# Altium schematic sheet MicroBitArcadeShieldMVD 08.SchDoc
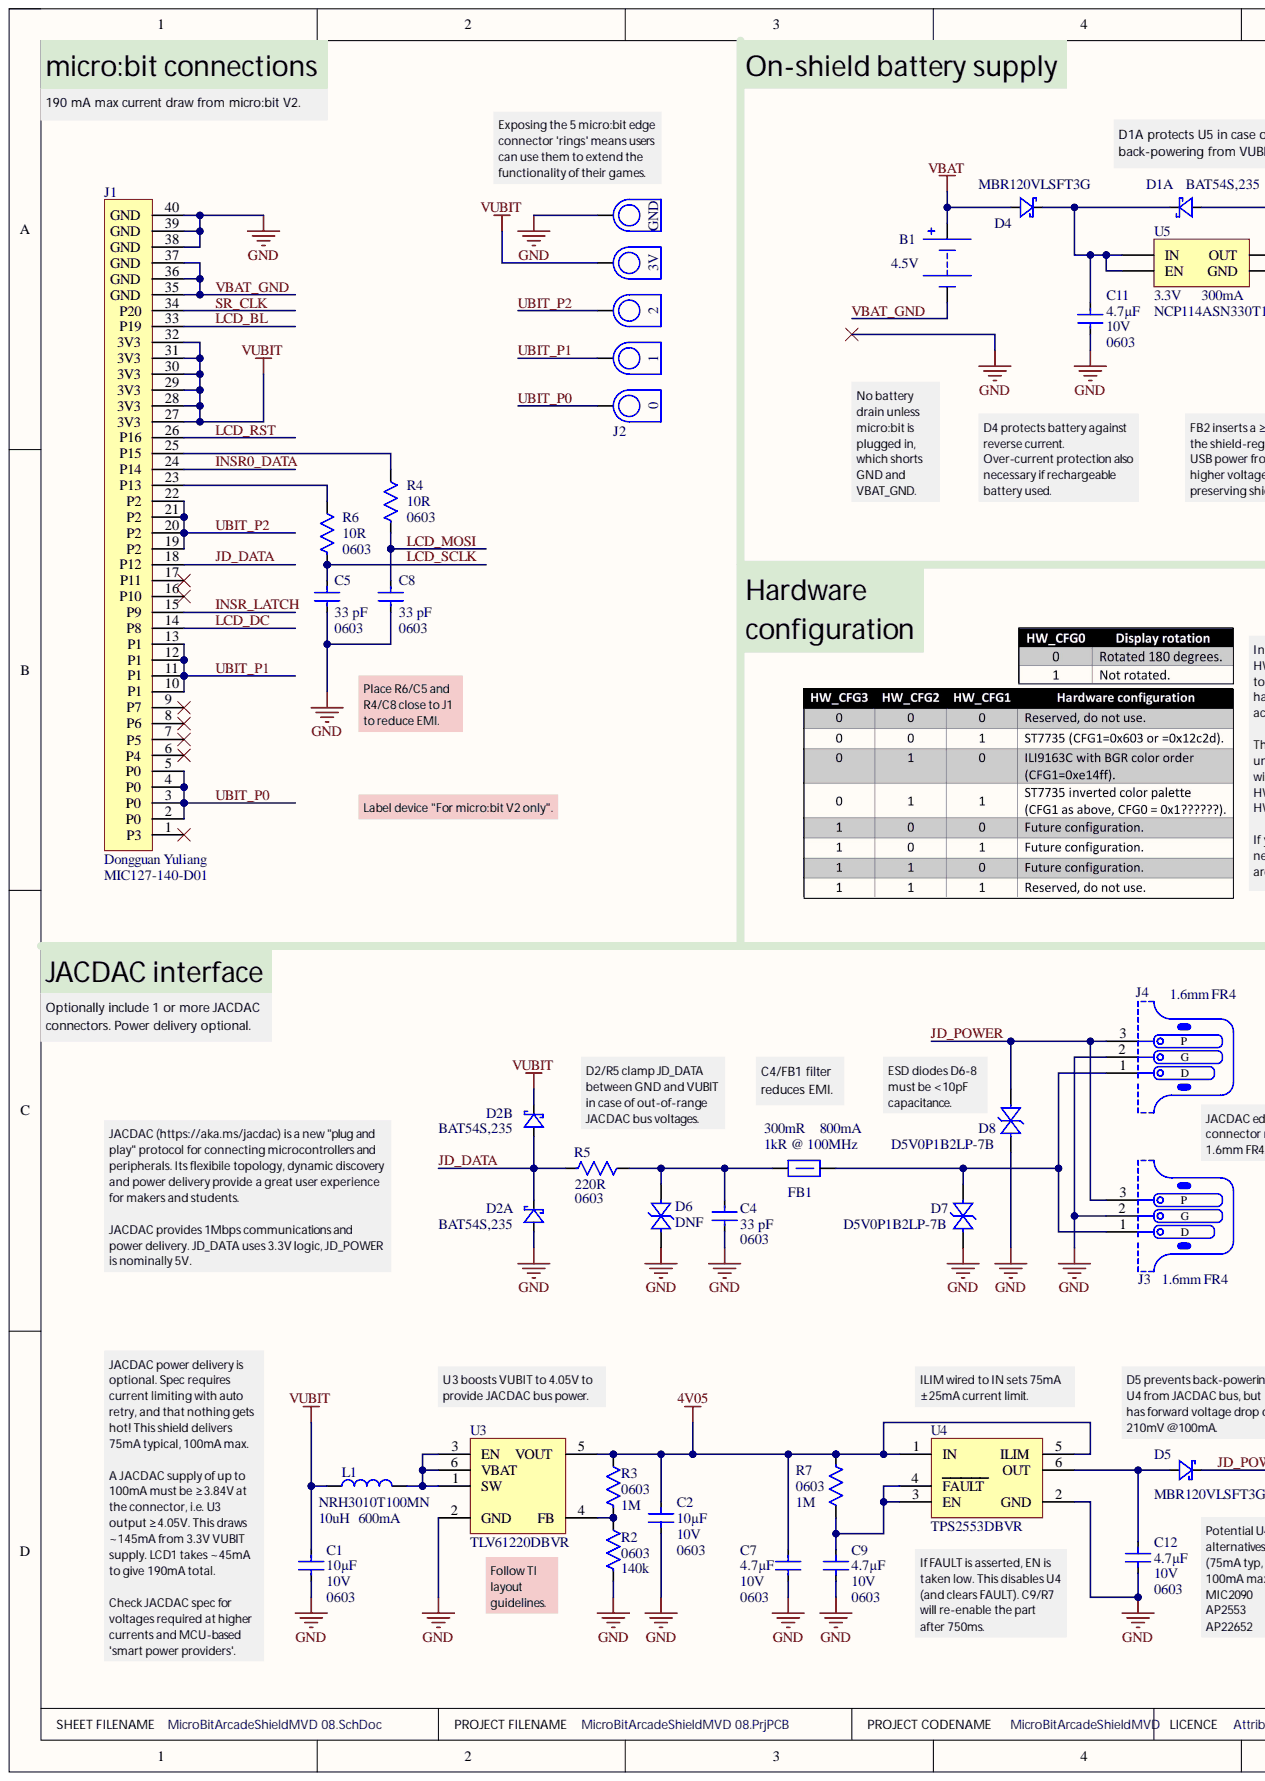
[diagram p0: MicroBitArcadeShieldMVD 08.SchDoc, left]
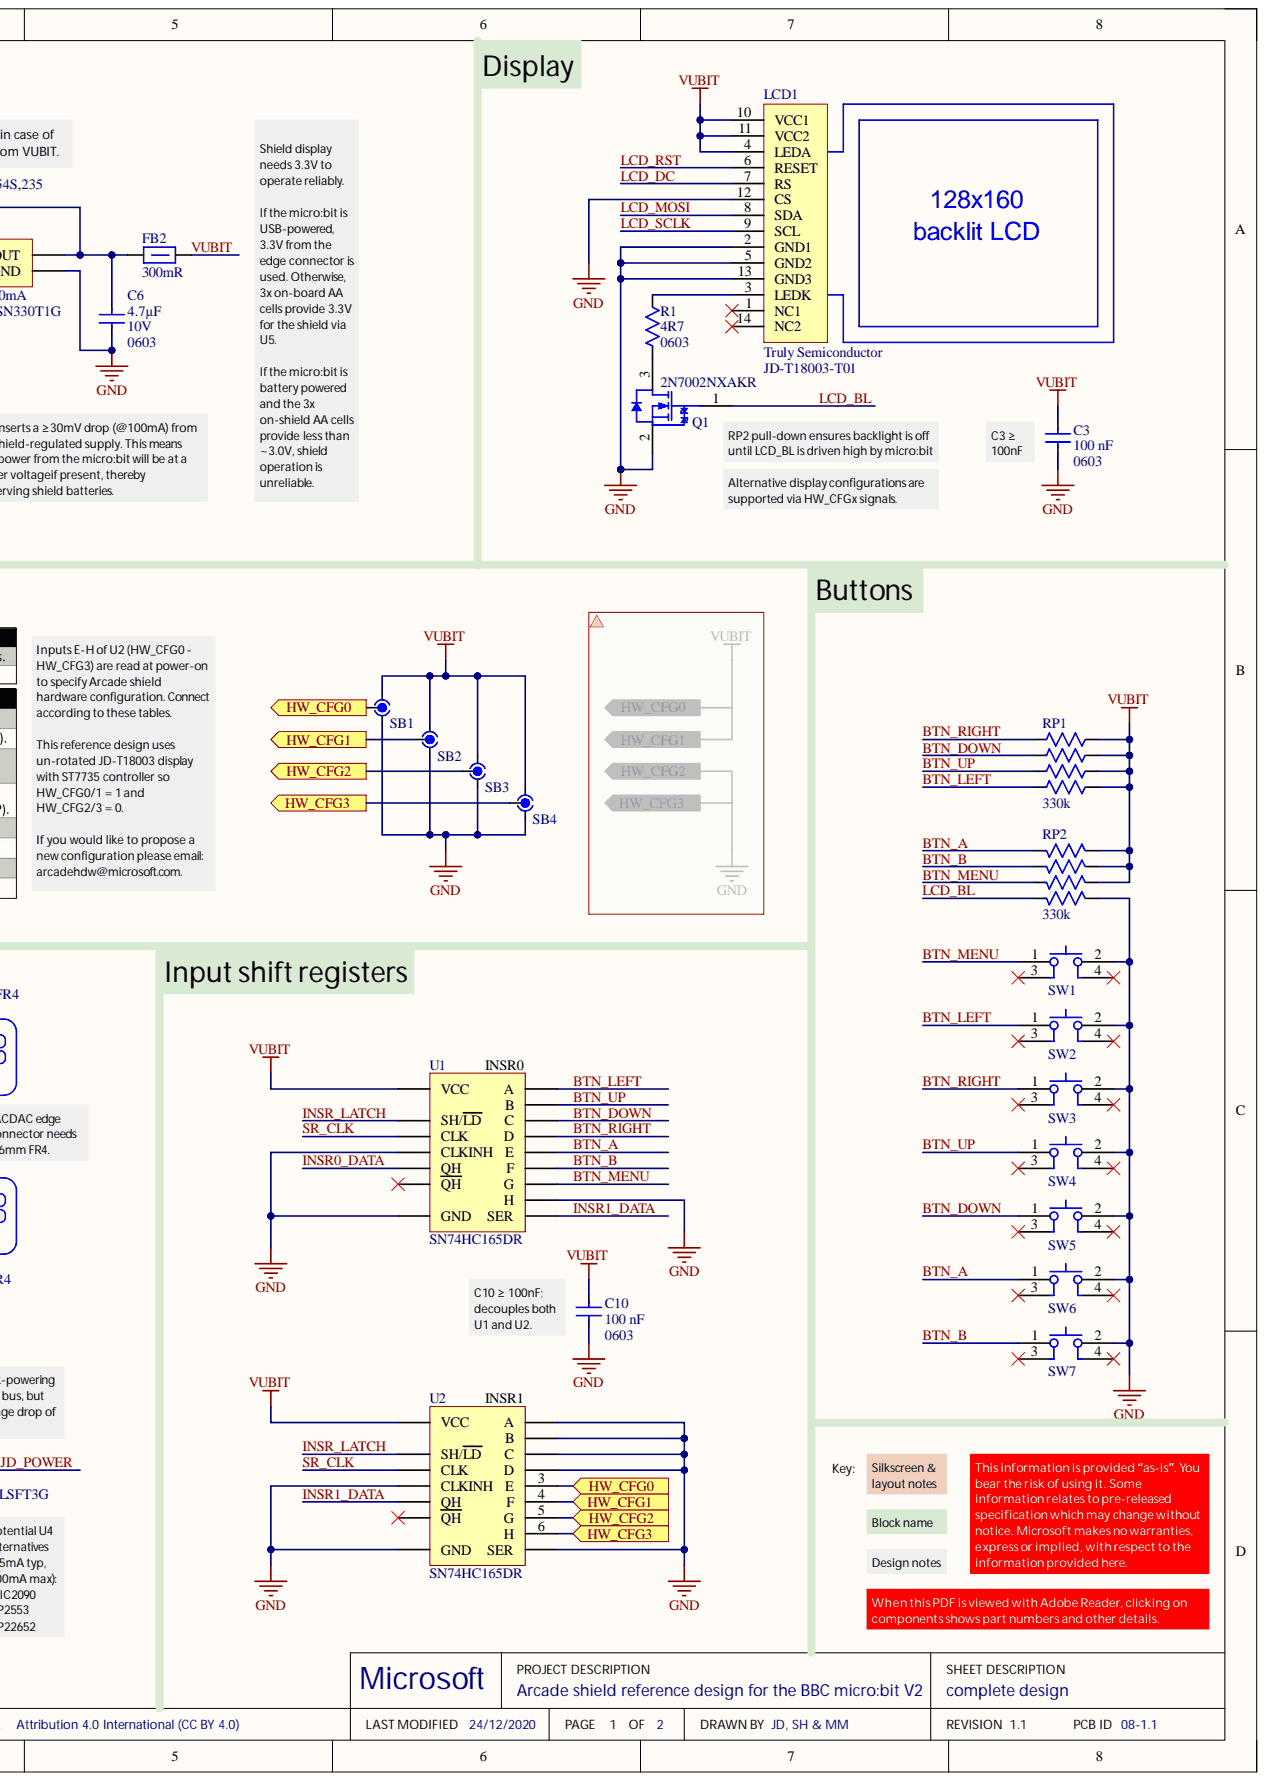
[diagram p1: MicroBitArcadeShieldMVD 08.SchDoc, right]

## Parts
B1  Nominal Voltage: 4.5V  [Keystone_2464]  (hidden comment: 2464)
C1  10µF  Case Code (Imperial): 0603  Voltage Rating: 10V  [C0603]
C2  10µF  Case Code (Imperial): 0603  Voltage Rating: 10V  [C0603]
C3  value 100 nF  Package Size: 0603  [CAP0603]  (hidden comment: CAP0603_GENERIC)
C4  value 33 pF  Package Size: 0603  [CAP0603]  (hidden comment: CAP0603_GENERIC)
C5  value 33 pF  Package Size: 0603  [CAP0603]  (hidden comment: CAP0603_GENERIC)
C6  Capacitance: 4.7µF  Case Code (Imperial): 0603  Voltage Rating: 10V  [CAP 0603 (1608) x 1.2mm]  (hidden comment: CL10A475KP8NNNC)
C7  Capacitance: 4.7µF  Case Code (Imperial): 0603  Voltage Rating: 10V  [CAP 0603 (1608) x 1.2mm]  (hidden comment: CL10A475KP8NNNC)
C8  value 33 pF  Package Size: 0603  [CAP0603]  (hidden comment: CAP0603_GENERIC)
C9  Capacitance: 4.7µF  Case Code (Imperial): 0603  Voltage Rating: 10V  [CAP 0603 (1608) x 1.2mm]  (hidden comment: CL10A475KP8NNNC)
C10  value 100 nF  Package Size: 0603  [CAP0603]  (hidden comment: CAP0603_GENERIC)
C11  Capacitance: 4.7µF  Case Code (Imperial): 0603  Voltage Rating: 10V  [CAP 0603 (1608) x 1.2mm]  (hidden comment: CL10A475KP8NNNC)
C12  Capacitance: 4.7µF  Case Code (Imperial): 0603  Voltage Rating: 10V  [CAP 0603 (1608) x 1.2mm]  (hidden comment: CL10A475KP8NNNC)
D1  BAT54S  Manufacturer Part Number: BAT54S,235  [NXP-BAT54S]  (part A)
D2  BAT54S  Manufacturer Part Number: BAT54S,235  [NXP-BAT54S]  (parts A,B)
D4  MBR120VLSFT3G  [MBR120VLSFT3G]
D5  MBR120VLSFT3G  [MBR120VLSFT3G]
D6  DNF  [D5V0P1B2LP]  (no BOM)
D7  D5V0P1B2LP  Manufacturer Part Number: D5V0P1B2LP-7B  [D5V0P1B2LP]
D8  D5V0P1B2LP  Manufacturer Part Number: D5V0P1B2LP-7B  [D5V0P1B2LP]
FB1  Current Rating: 800mA  DC Resistance (DCR): 300mR  Impedance: 1kR @ 100MHz  [TDK MPZ1608 0.8mm]  (hidden comment: TDK MPZ1608S102ATA00)
FB2  DC Resistance (DCR): 300mR  [TDK MPZ1608 0.8mm]  (hidden comment: TDK MPZ1608S102ATA00)
J1  Manufacturer: Dongguan Yuliang  [MIC127-140-D01]  (hidden comment: Planar 1x40p 1.27 mm pitch edge connector for the micro:bit)
J2  Only the rings of the micro:bit edge connector.  [MICROBIT_V2_EDGE_CONNECTOR_RINGS]  (value not drawn)  (no BOM)
J3  PCB Type: 1.6mm FR4  [JACDAC_EDGE_CONNECTOR]  (hidden comment: 3 pin jacdac edge connector)  (no BOM)
J4  PCB Type: 1.6mm FR4  [JACDAC_EDGE_CONNECTOR]  (hidden comment: 3 pin jacdac edge connector)  (no BOM)
L1  NRH3010T100MN  Inductance: 10uH  Max DC Current: 600mA  [NRH3010T100MN]
LCD1  Manufacturer: Truly Semiconductor  Manufacturer Part Number: JD-T18003-T01  [JD-T18003-T01-BASIC]  (hidden comment: JD-T18003-T01-reorg)
Q1  2N7002NXAKR  [2N7002NXAK]
R1  value 4R7  Package Size: 0603  [RES0603]  (hidden comment: RES0603_GENERIC)
R2  value 140k  Package Size: 0603  [RES0603]  (hidden comment: RES0603_GENERIC)
R3  value 1M  Package Size: 0603  [RES0603]  (hidden comment: RES0603_GENERIC)
R4  value 10R  Package Size: 0603  [RES0603]  (hidden comment: RES0603_GENERIC)
R5  value 220R  Package Size: 0603  [RES0603]  (hidden comment: RES0603_GENERIC)
R6  value 10R  Package Size: 0603  [RES0603]  (hidden comment: RES0603_GENERIC)
R7  value 1M  Package Size: 0603  [RES0603]  (hidden comment: RES0603_GENERIC)
RP1  Resistance: 330k  [EXB-38V334JV]  (hidden comment: Res Thick Film Array 330K Ohm)
RP2  Resistance: 330k  [EXB-38V334JV]  (hidden comment: Res Thick Film Array 330K Ohm)
SB1  Three way open solder blob  [ThreeWaySolderBlob]  (value not drawn)  (no BOM)
SB2  Three way open solder blob  [ThreeWaySolderBlob]  (value not drawn)  (no BOM)
SB3  Three way open solder blob  [ThreeWaySolderBlob]  (value not drawn)  (no BOM)
SB4  Three way open solder blob  [ThreeWaySolderBlob]  (value not drawn)  (no BOM)
SW1  Tact Wurth 430152050826  [Wurth 430152050836-WIDE]  (value not drawn)
SW2  Tact Wurth 430152050826  [Wurth 430152050836-WIDE]  (value not drawn)
SW3  Tact Wurth 430152050826  [Wurth 430152050836-WIDE]  (value not drawn)
SW4  Tact Wurth 430152050826  [Wurth 430152050836-WIDE]  (value not drawn)
SW5  Tact Wurth 430152050826  [Wurth 430152050836-WIDE]  (value not drawn)
SW6  Tact Wurth 430152050826  [Wurth 430152050836-WIDE]  (value not drawn)
SW7  Tact Wurth 430152050826  [Wurth 430152050836-WIDE]  (value not drawn)
U1  Note: INSR0  [TI-SOIC16]  (hidden comment: 8-BIT SHIFT/LOAD (INPUT) REGISTER, 16-SOIC)
U2  Note: INSR1  [TI-SOIC16]  (hidden comment: 8-BIT SHIFT/LOAD (INPUT) REGISTER, 16-SOIC)
U3  TLV61220  Manufacturer Part Number: TLV61220DBVR  [TI-TLV61220]
U4  TPS2553DBVR  [TI-TPS2553]
U5  Min Output Voltage: 3.3V  Output Current: 300mA  [ON_SEMI-SOT23-5]  (hidden comment: LDO 3.3V/300 mA)

## Ports
HW_CFG0, HW_CFG1, HW_CFG2, HW_CFG3

## Nets
4V05: C2.2 C7.2 R3.1 R7.2 U3.5(VOUT) U4.1(IN) U4.5(ILIM)
GND: C1.1 C2.1 C3.1 C4.1 C5.1 C6.1 C7.1 C8.1 C9.1 C10.1 C11.1 C12.1 D2.1 D6.2 D7.2 D8.2 J1.38(GND) J1.39(GND) J1.40(GND) J2.5 J3.2 J4.2 LCD1.2(GND1) LCD1.5(GND2) LCD1.12(CS) LCD1.13(GND3) Q1.2 R2.2 RP2.8 SB1.1 SB2.1 SB3.1 SB4.1 SW1.2 SW2.2 SW3.2 SW4.2 SW5.2 SW6.2 SW7.2 U1.6(H) U1.8(GND) U1.15(CLKINH) U2.8(GND) U2.10(SER) U2.11(A) U2.12(B) U2.13(C) U2.14(D) U2.15(CLKINH) U3.2(GND) U4.2(GND) U5.2(GND)
VBAT: B1.1 D4.2
BTN_A: RP2.4 SW6.1 U1.3(E)
BTN_B: RP2.3 SW7.1 U1.4(F)
BTN_DOWN: RP1.2 SW5.1 U1.13(C)
BTN_LEFT: RP1.4 SW2.1 U1.11(A)
BTN_MENU: RP2.2 SW1.1 U1.5(G)
BTN_RIGHT: RP1.1 SW3.1 U1.14(D)
BTN_UP: RP1.3 SW4.1 U1.12(B)
HW_CFG0: SB1.2 U2.3(E) ; port HW_CFG0
HW_CFG1: SB2.2 U2.4(F) ; port HW_CFG1
HW_CFG2: SB3.2 U2.5(G) ; port HW_CFG2
HW_CFG3: SB4.2 U2.6(H) ; port HW_CFG3
INSR0_DATA: J1.24(P14) U1.9(QH)
INSR1_DATA: U1.10(SER) U2.9(QH)
INSR_LATCH: J1.15(P9) U1.1(SH/LD) U2.1(SH/LD)
JD_DATA: D2.3 J1.18(P12) R5.2
JD_POWER: D5.1 D8.1 J3.3 J4.3
LCD_BL: J1.33(P19) Q1.1 RP2.1
LCD_DC: J1.14(P8) LCD1.7(RS)
LCD_MOSI: C8.2 LCD1.8(SDA) R4.2
LCD_RST: J1.26(P16) LCD1.6(RESET)
LCD_SCLK: C5.2 LCD1.9(SCL) R6.2
SR_CLK: J1.34(P20) U1.2(CLK) U2.2(CLK)
UBIT_P0: J1.2(P0) J1.3(P0) J1.4(P0) J1.5(P0) J2.1
UBIT_P1: J1.10(P1) J1.11(P1) J1.12(P1) J1.13(P1) J2.2
UBIT_P2: J1.19(P2) J1.20(P2) J1.21(P2) J1.22(P2) J2.3
VBAT_GND: B1.2 J1.35(GND) J1.36(GND) J1.37(GND)
VUBIT: C1.2 C3.2 C10.2 D2.2 FB2.2 J1.27(3V3) J1.28(3V3) J1.29(3V3) J1.30(3V3) J1.31(3V3) J1.32(3V3) J2.4(3V) L1.1 LCD1.4(LEDA) LCD1.10(VCC1) LCD1.11(VCC2) RP1.5 RP1.6 RP1.7 RP1.8 RP2.5 RP2.6 RP2.7 SB1.3 SB2.3 SB3.3 SB4.3 U1.16(VCC) U2.16(VCC)
NetC4_2: C4.2 D6.1 FB1.1 R5.1
NetC6_2: C6.2 D1.1 FB2.1 U5.5(OUT)
NetC9_2: C9.2 R7.1 U4.3(EN) U4.4(FAULT)
NetC11_2: C11.2 D1.3 D4.1 U5.1(IN) U5.3(EN)
NetC12_2: C12.2 D5.2 U4.6(OUT)
NetD7_1: D7.1 FB1.2 J3.1 J4.1
NetJ1_23: J1.23(P13) R6.1
NetJ1_25: J1.25(P15) R4.1
NetL1_2: L1.2 U3.1(SW) U3.3(EN) U3.6(VBAT)
NetLCD1_3: LCD1.3(LEDK) R1.1
NetQ1_3: Q1.3 R1.2
NetR2_1: R2.1 R3.2 U3.4(FB)

Not connected: J1 1(P3) 6(P4) 7(P5) 8(P6) 9(P7) 16(P10) 17(P11); LCD1 1(NC1) 14(NC2); SW1 3 4; SW2 3 4; SW3 3 4; SW4 3 4; SW5 3 4; SW6 3 4; SW7 3 4; U1 7(QH); U2 7(QH)

## Library data of the parts
B1 = 2464: Battery Holder;  AA;  Polypropylene;  PC Mount;  3;  Spring;  PC; Manufacturer: Keystone Electronics; Short Manufacturer: Keystone; Number of Batteries: 3; Wire/Cable Gauge: 26AWG; Body Material: Polypropylene; Category: Batteries and Accessories; Component Kind: Standard; ComponentLink4Description: Keystone datasheet online; ComponentLink4URL: https://datasheet.ciiva.com/14603/70182746-14603614.pdf; Contact Material: Brass; Contact Plating: Nickel; Lead Free: Lead Free; Library Name: Keystone.SchLib; Manufacturer Part Number: 2464; Material: Steel,Plastic; Mount: Through Hole,PCB; Number of Cells: 3; Package Quantity: 1; Packaging: Bulk; REACH SVHC: No SVHC; RoHS: Yes; Supplier 1: Digi-Key; Supplier 2: Farnell; Supplier 3: Mouser; Supplier Part Number 1: 2464K-ND; Supplier Part Number 2: 1704231; Supplier Part Number 3: 534-2464; Termination: PC Pin
C1 C2 = CL10A106KP8NNNC: Generic 10uF 10V 0603 X5R cap, e.g. Samsung CL10A106KP8NNNC; Material: Ceramic; Tolerance: 10%; Capacitance: 10uF; Case Code (Metric): 1608; Case/Package: 0603; Category: Ceramic Capacitors; Component Kind: Standard; ComponentLink1Description: Samsung specification online; ComponentLink1URL: https://datasheet.ciiva.com/26877/getdatasheetpartid-359551-26877881.pdf; ComponentLink2Description: Samsung databook online; ComponentLink2URL: https://datasheet.ciiva.com/29198/457369-da-01-en-vs-kondensator-0603-29198790.pdf; ComponentLink3Description: Samsung datasheet online; ComponentLink3URL: https://datasheet.ciiva.com/16200/cl05b104kq5nnnc-16200766.pdf; Contact Plating: Tin; Depth: 0.8mm; Dielectric: X5R; Height: 0.8mm; Lead Free: Lead Free; Length: 1.6mm; Library Name: Samsung.SchLib; Manufacturer: Samsung; Manufacturer Part Number: CL10A106KP8NNNC; Max Operating Temperature: 85°C; Min Operating Temperature: -55°C; Mount: Surface Mount; Package Quantity: 1; Packaging: Tape and Reel; Pricing 1: 1=0.21000, 10=0.14800, 50=0.08420, 100=0.06980, 500=0.04892, 1000=0.04050 (USD); Pricing 2: 1=0.19000, 10=0.13200, 100=0.06700, 500=0.04500, 1000=0.04000, 2500=0.03400 (USD); Pricing 3: 10=0.08380, 100=0.06930, 500=0.04170, 1000=0.03410, 2000=0.03370, 4000=0.02700, 20000=0.02200 (GBP); Radiation Hardening: No; RoHS: Yes; Stock 1: 1098575; Stock 2: 194338; Stock 3: 11777; Supplier 1: Digi-Key; Supplier 2: Mouser; Supplier 3: Farnell; Supplier Part Number 1: 1276-1192-1-ND; Supplier Part Number 2: 187-CL10A106KP8NNNC; Supplier Part Number 3: 3013386; Thickness: 0.035inch; Voltage Rating (DC): 10V; Width: 0.031inch
C3-C5 C8 C10 = CAP0603_GENERIC: Generic 0603 capacitor; Rated Voltage: * V; Component Kind: Standard; Library Name: generic-cap.SchLib; Manufacturer: Generic
C6 C7 C9 C11 C12 = 4.7uF 10V X5R ±10% Samsung CL: Generic 4.7uF 10V X5R 0603 cap, e.g. Samsung CL10A475KP8NNNC; Tolerance: 10%; Case Code (Metric): 1608; Case/Package: 0603; Component Kind: Standard; Contact Plating: Tin; Depth: 0.8mm; Dielectric: X5R; Height: 0.8mm; Length: 1.6mm; Library Name: Samsung.SchLib; Manufacturer: Samsung; Manufacturer Part Number: CL10A475KP8NNNC; Max Operating Temperature: 85°C; Min Operating Temperature: -55°C; Mount: Surface Mount; Package Quantity: 1; Packaging: Tape and Reel; Pricing 1: 1=0.15, 10=0.109, 100=0.0513, 500=0.036, 1000=0.0298 (USD); Radiation Hardening: No; Stock 1: 40000; Supplier 1: Digi-Key; Supplier 2: Farnell; Supplier Part Number 1: 1276-1044-1-ND; Supplier Part Number 2: 3010071; Thickness: 0.035inch; Width: 0.031inch; Created By: shodges
D1 D2 = BAT54S: DIODE ARRAY SCHOTTKY 30V SOT23; Manufacturer: Nexperia; Category: Rectifier Diodes; Component Kind: Standard; ComponentLink1Description: NXP datasheet online; ComponentLink1URL: https://assets.nexperia.com/documents/data-sheet/BAT54_SER.pdf; Library Name: NXP.SchLib; Pricing 1: 1=0.13000, 10=0.11900, 100=0.05610, 500=0.03326, 1000=0.02185, 5000=0.02138 (USD); RoHS: No; Stock 1: 14011; Supplier 1: Digi-Key; Supplier 2: Mouser; Supplier 3: RSComponents; Supplier Part Number 1: 1727-1868-1-ND; Supplier Part Number 2: 771-BAT54S235; Supplier Part Number 3: 1707990
D4 D5 = MBR120VLSFT3G: DIODE SCHOTTKY 20V 1A SOD123FL; Manufacturer: ON Semiconductor; Element Configuration: Single; Forward Voltage: 340mV; Max Forward Surge Current (Ifsm): 45A; Max Reverse Leakage Current: 600uA; Polarity: Standard; Average Rectified Current: 1A; Category: Schottky Diodes; Component Kind: Standard; ComponentLink4Description: On Semi datasheet online; ComponentLink4URL: https://datasheet.ciiva.com/6380/mbr120vlsft1-d-6380910.pdf; Current Rating: 1A; Forward Current: 1A; Halogen Free: Halogen Free; Lead Free: Lead Free; Library Name: OnSemiconductor.SchLib; Manufacturer Part Number: MBR120VLSFT3G; Max Operating Temperature: 125°C; Max Repetitive Reverse Voltage (Vrrm): 20V; Max Reverse Voltage (DC): 20V; Max Surge Current: 45A; Min Operating Temperature: -65°C; Number of Pins: 2; Output Current: 1A; Package Quantity: 10000; Packaging: Tape and Reel; Peak Non-Repetitive Surge Current: 45A; Peak Reverse Current: 600uA; Pricing 1: 1=0.39000, 10=0.28600, 100=0.19920, 500=0.12616, 1000=0.10292, 2000=0.09960, 5000=0.09628 (USD); Radiation Hardening: No; REACH SVHC: No SVHC; RoHS: Yes; Stock 1: 32481; Supplier 1: Digi-Key; Supplier 2: Mouser; Supplier 3: RSComponents; Supplier Part Number 1: MBR120VLSFT3GOSCT-ND; Supplier Part Number 2: 863-MBR120VLSFT3G; Supplier Part Number 3: 1867479; Voltage Rating (DC): 20V
D6 = D5V0P1B2LP: ESD Suppressor, low parasitic capacitance.TVS DIODE 5.5V 13V DFN1006-2; Manufacturer: Diodes; Manufacturer Part Number: D5V0P1B2LP-7B; Breakdown Voltage: 7V; Direction: Bidirectional; Element Configuration: Single; ESD Protection: Yes; Max Power Dissipation: 250mW; Max Reverse Leakage Current: 100nA; Max Surge Current: 4A; Min Breakdown Voltage: 6V; Number of Bidirectional Channels: 1; Power Line Protection: No; Case/Package: DFN; Category: TVS Diodes; Clamping Voltage: 13V; ComponentLink3Description: Diodes Inc datasheet online; ComponentLink3URL: https://www.diodes.com/assets/Datasheets/D5V0P1B2LP.pdf; Contact Plating: Gold; Library Name: DiodesInc.SchLib; Max Operating Temperature: 150°C; Min Operating Temperature: -65°C; Mount: Surface Mount; Number of Pins: 2; Package Quantity: 10000; Packaging: Tape and Reel; Peak Pulse Current: 4A; Peak Pulse Power: 250mW; Polarity: Bidirectional; Pricing 1: 1=0.25000, 10=0.17500, 100=0.09310, 500=0.05332, 1000=0.04460, 2000=0.03684, 5000=0.03490 (USD); Resistor Type: Zener; Reverse Standoff Voltage: 5.5V; RoHS: Yes; Stock 1: 69866; Supplier 1: Digi-Key; Supplier 2: Mouser; Supplier Part Number 1: D5V0P1B2LP-7BDICT-ND; Supplier Part Number 2: 621-D5V0P1B2LP-7B; Termination: SMD/SMT; Working Voltage: 5.5V
D7 D8 = D5V0P1B2LP: ESD Suppressor, low parasitic capacitance.TVS DIODE 5.5V 13V DFN1006-2; Manufacturer: Diodes; Breakdown Voltage: 7V; Direction: Bidirectional; Element Configuration: Single; ESD Protection: Yes; Max Power Dissipation: 250mW; Max Reverse Leakage Current: 100nA; Max Surge Current: 4A; Min Breakdown Voltage: 6V; Number of Bidirectional Channels: 1; Power Line Protection: No; Case/Package: DFN; Category: TVS Diodes; Clamping Voltage: 13V; Component Kind: Standard; ComponentLink3Description: Diodes Inc datasheet online; ComponentLink3URL: https://www.diodes.com/assets/Datasheets/D5V0P1B2LP.pdf; Contact Plating: Gold; Library Name: DiodesInc.SchLib; Max Operating Temperature: 150°C; Min Operating Temperature: -65°C; Mount: Surface Mount; Number of Pins: 2; Package Quantity: 10000; Packaging: Tape and Reel; Peak Pulse Current: 4A; Peak Pulse Power: 250mW; Polarity: Bidirectional; Pricing 1: 1=0.25000, 10=0.17500, 100=0.09310, 500=0.05332, 1000=0.04460, 2000=0.03684, 5000=0.03490 (USD); Resistor Type: Zener; Reverse Standoff Voltage: 5.5V; RoHS: Yes; Stock 1: 69866; Supplier 1: Digi-Key; Supplier 2: Mouser; Supplier Part Number 1: D5V0P1B2LP-7BDICT-ND; Supplier Part Number 2: 621-D5V0P1B2LP-7B; Termination: SMD/SMT; Working Voltage: 5.5V
FB1 = TDK MPZ1608S102ATA00: 0603 1k@100MHz 0.8A chip; Core Material: Ferrite; Tolerance: 25%; Case Code (Imperial): 0603; Case Code (Metric): 1608; Case/Package: 0603; Component Kind: Standard; ComponentLink2Description: datasheet online; ComponentLink2URL: https://www.mouser.co.uk/datasheet/2/400/eads_commercial_power_mpz1608_en-923650.pdf; ComponentLink3Description: datasheet; Height: 0.037inch; Length: 0.063inch; Library Name: TDK.SchLib; Manufacturer: TDK; Manufacturer Part Number: MPZ1608S102ATA00; Max DC Current: 800mA; Mount: Surface Mount; Package Quantity: 4000; Packaging: Tape and Reel; Pricing 1: 1=0.1, 10=0.079, 25=0.0716, 50=0.0644, 100=0.0472, 250=0.03432, 500=0.03146, 1000=0.02717 (USD); Stock 1: 113542; Supplier 1: Digi-Key; Supplier 2: Mouser; Supplier Part Number 1: 445-8694-1-ND; Supplier Part Number 2: 810-MPZ1608S102ATA00; Width: 0.031inch; ComponentLink3URL: Y:\System\Altium\Libraries 2014\TDK\Datasheets\eads_commercial_power_mpz1608_en-923650.pdf
FB2 = TDK MPZ1608S102ATA00: 0603 1k@100MHz 0.8A chip; Core Material: Ferrite; Current Rating: 800mA; Impedance: 1kR @ 100MHz; Tolerance: 25%; Case Code (Imperial): 0603; Case Code (Metric): 1608; Case/Package: 0603; Component Kind: Standard; ComponentLink2Description: datasheet online; ComponentLink2URL: https://www.mouser.co.uk/datasheet/2/400/eads_commercial_power_mpz1608_en-923650.pdf; ComponentLink3Description: datasheet; Height: 0.037inch; Length: 0.063inch; Library Name: TDK.SchLib; Manufacturer: TDK; Manufacturer Part Number: MPZ1608S102ATA00; Max DC Current: 800mA; Mount: Surface Mount; Package Quantity: 4000; Packaging: Tape and Reel; Pricing 1: 1=0.1, 10=0.079, 25=0.0716, 50=0.0644, 100=0.0472, 250=0.03432, 500=0.03146, 1000=0.02717 (USD); Stock 1: 113542; Supplier 1: Digi-Key; Supplier 2: Mouser; Supplier Part Number 1: 445-8694-1-ND; Supplier Part Number 2: 810-MPZ1608S102ATA00; Width: 0.031inch; ComponentLink3URL: Y:\System\Altium\Libraries 2014\TDK\Datasheets\eads_commercial_power_mpz1608_en-923650.pdf
J1 = MIC127-140-D01: "Same plane" 1x40p 1.27mm Dongguan Yuliang BBC micro:bit edge connector; Component Kind: Standard; ComponentLink1Description: Yuliang drawing; ComponentLink2Description: Yuliang drawing online; ComponentLink2URL: http://www.dgyuliang.net/d/file/Produtcs/Customized%20Connector/MICRO%20BIT%20Connector/aff70b8859d81d58072ddeb323d41b1d.pdf; Library Name: Yuliang.SchLib; Manufacturer Part Number: MIC127-140-D01; ComponentLink1URL: Y:\System\Altium\Libraries 2014\Yuliang\Datasheets\YULIANG-MIC127-140-D01.pdf
J2 = micro:bit edge connector rings: Rings from the micro:bit edge connector designed to take a 4mm banana plug.; Library Name: generic-con.SchLib
J3 J4 = JACDAC edge connector: 3 pin jacdac edge connector 1.27mm pitch; ComponentLink1Description: JACDAC PCB edge connector spec onlin; ComponentLink1URL: https://github.com/microsoft/jacdac-mdk/blob/main/connector/JACDAC_PCB_Edge_Connector_Drawing_JD-PEC-01_rev_01.pdf; ComponentLink2Description: Yuliang JACDAC cable connector drawing online; ComponentLink2URL: https://github.com/microsoft/jacdac-mdk/blob/main/connector/JACDAC-Connector-by-Yuliang-2020-11-28.pdf; Library Name: generic-con.SchLib; Manufacturer Part Number: JACDAC_EDGE_CONNECTOR; Checked By: ?; Created By: D. Gakure
L1 = NRH3010T100MN: Inductor, Shielded, 10uH, 600mA, SMD; NRH Series; Inductance:10 H; Inductance Tolerance: 20%; Inductor Construction:Shielded; DC Resistance Max:0.35ohm; RMS Current (Irms):620mA; Saturation Current (Isat):600mA ;RoHS Compliant: Yes; Manufacturer: Taiyo Yuden; Core Material: Ferrite; Current Rating: 620mA; DC Resistance (DCR): 350mR; Self Resonant Frequency: 34MHz; Test Frequency: 100kHz; Case/Package: 3010; Category: Fixed Inductors; Component Kind: Standard; ComponentLink2Description: Taiyo Yuden spec sheet online; ComponentLink2URL: https://datasheet.ciiva.com/1043/nrh3010t100mn-ss-1043273.pdf; ComponentLink3Description: Taiyo Yuden data sheet (2015) online; ComponentLink3URL: https://datasheet.ciiva.com/8002/wound04-e-8002182.pdf?src-supplier=Element14; Composition: Wirewound; Depth: 3.1mm; Halogen Free: Halogen Free; Height: 1mm; Height - Seated (Max): 0.039inch; Lead Free: Lead Free; Length: 3mm; Library Name: TaiyoYuden .SchLib; Manufacturer Part Number: NRH3010T100MN; Max Operating Temperature: 120°C; Min Operating Temperature: -25°C; Mount: Surface Mount; Package Quantity: 2000; Packaging: Tape and Reel; Pricing 1: 1=0.31000, 10=0.27600, 25=0.24120, 50=0.20680, 100=0.18950, 250=0.17224, 500=0.16192, 1000=0.12402 (USD); Radiation Hardening: No; REACH SVHC: Unknown; RMS Current (Irms): 620mA; RoHS: Yes; Saturation Current: 600mA; Shielding: Shielded; Stock 1: 2000; Supplier 1: Digi-Key; Supplier 2: Mouser; Supplier Part Number 1: 587-2575-1-ND; Supplier Part Number 2: 963-NRH3010T100MN; Termination: SMD/SMT; Tolerance: 20%; Width: 3mm
LCD1 = JD-T18003-T01-reorg: 128x160 backlit colour LCD; Component Kind: Standard; ComponentLink1Description: Truly Semiconductor datasheet; ComponentLink1URL: https://cdn-shop.adafruit.com/datasheets/JD-T1800.pdf; ComponentLink2Description: Adafruit product page; ComponentLink2URL: https://www.adafruit.com/product/618; Library Name: TrulySemiconductor.SchLib; Pricing 1: 1=9.95 (USD); Stock 1: 65; Supplier 1: Digi-Key; Supplier 2: Mouser; Supplier 3: Adafruit; Supplier Part Number 1: 1528-2562-ND; Supplier Part Number 2: 485-618; Supplier Part Number 3: 618
Q1 = 2N7002NXAKR: 2N7002NXAK - 60 V, single N-channel Trench MOSFET; Manufacturer: Nexperia; Component Kind: Standard; ComponentLink1Description: Nexperia datasheet online; ComponentLink1URL: https://assets.nexperia.com/documents/data-sheet/2N7002NXAK.pdf; Library Name: NXP.SchLib; Manufacturer Part Number: 2N7002NXAKR; Pricing 1: 1=0.10000, 10=0.07600, 25=0.07240, 100=0.03190, 500=0.02506, 1000=0.01740 (USD); RoHS: No; Stock 1: 107263; Supplier 1: Digi-Key; Supplier 2: Farnell; Supplier 3: Mouser; Supplier Part Number 1: 1727-8643-1-ND; Supplier Part Number 2: 3226400; Supplier Part Number 3: 771-2N7002NXAKR
R1 R4-R6 = RES0603_GENERIC: Generic 0603 resistor; Power: *mW; Tolerance: 5%; Component Kind: Standard; Library Name: generic-res.SchLib; Manufacturer: Generic
R2 R3 R7 = RES0603_GENERIC: Generic 0603 resistor; Power: *mW; Tolerance: 1%; Component Kind: Standard; Library Name: generic-res.SchLib; Manufacturer: Generic
RP1 RP2 = EXB-38V334JV: Res Thick Film Array 330K Ohm 5% 200ppm/C ISOL Molded 8-Pin 1206(4 X 0603) Convex SMD Punched Carrier T/R; Manufacturer: Panasonic; Circuit Type: Isolated; Number of Contacts: 8; Number of Resistors: 4; Power Per Element: 62.5mW; Temperature Coefficient: 200ppm/°C; Voltage Rating (DC): 100V; Case Code (Imperial): 1206; Case Code (Metric): 3216; Case/Package: 1206; Category: Resistor Arrays; Component Kind: Standard; ComponentLink2Description: Panasonic datasheet online; ComponentLink2URL: https://media.digikey.com/pdf/Data%20Sheets/Panasonic%20Resistors%20Thermistors%20PDFs/EXB38V%20Spec.pdf; Height: 0.024inch; Lead Free: Contains Lead; Length: 0.126inch; Library Name: Panasonic.SchLib; Manufacturer Part Number: EXB-38V334JV; Max Operating Temperature: 125°C; Min Operating Temperature: -55°C; Mount: Surface Mount; Number of Pins: 8; Number of Terminals: 8; Package Quantity: 1; Packaging: Tape and Reel; Pricing 1: 1=0.10000, 10=0.08300, 25=0.05720, 50=0.04460, 100=0.03180, 250=0.02480, 500=0.01972, 1000=0.01399 (USD); Radiation Hardening: No; RoHS: Yes; Stock 1: 38850; Supplier 1: Digi-Key; Supplier 2: Mouser; Supplier Part Number 1: Y9334CT-ND; Supplier Part Number 2: 667-EXB-38V334JV; Terminal Pitch: 0.8mm; Termination: SMD/SMT; Tolerance: 5%; Width: 0.063inch
SB1-SB4 = ThreeWaySolderBlob: Description: Three way open solder blob; Library Name: generic.SchLib
SW1-SW7 = Tact Wurth 430152050826: Generic 6x6mm SPST button e,g, Wurth 430152050826; Manufacturer: Wurth Electronics; Actuator Length: 1.6mm; Actuator Material: Polymer,Liquid Crystal Polymer; Actuator Type: Standard; Contact Current Rating: 50mA; Illumination Type: Non-Illuminated,Illuminated; Mechanical Life: 200000Cycles; Operating Force: 260gf; Switch Function: Off-Mom; Switch Travel: 0.25mm; Component Kind: Standard; ComponentLink1Description: wurth datasheet online; ComponentLink1URL: http://www.farnell.com/datasheets/1910150.pdf?src-supplier=Element14; ComponentLink2Description: wurth datasheet; Contact Material: Stainless Steel,Steel; Current Rating: 50mA; Height: 5mm; Length: 6.2mm; Library Name: Wurth.SchLib; Manufacturer Part Number: 430152050826; Max Operating Temperature: 85°C; Min Operating Temperature: -40°C; Mount: Surface Mount; Packaging: Tape and Reel; Pricing 1: 1=0.47, 500=0.38232 (USD); REACH SVHC: No SVHC; Stock 1: 2282; Supplier 1: Digi-Key; Supplier 2: Farnell; Supplier 3: RSComponents; Supplier Part Number 1: 732-6993-1-ND; Supplier Part Number 2: 2065110; Supplier Part Number 3: 7856213; Termination: Gull Wing; Throw Configuration: SPST; Width: 6.2mm; ComponentLink2URL: Y:\System\Altium\Libraries 2014\Wurth\Datasheets\4301x2xxx8x6 1910150.pdf
U1 U2 = SN74HC165DR: Manufacturer: Texas Instruments; Direction: Unidirectional; Logic Function: Shift Register,OR; Max Supply Voltage: 6V; Min Supply Voltage: 2V; Number of Bits: 8; Number of Circuits: 1; Number of Input Lines: 9; Operating Supply Voltage: 5V; Propagation Delay: 26ns; Quiescent Current: 8uA; Turn-On Delay Time: 190ns; Case/Package: SOIC; Category: Shift Registers; Component Kind: Standard; ComponentLink7Description: TI datasheet online; ComponentLink7URL: https://datasheet.ciiva.com/46397/1831348-46397223.pdf; Contact Plating: Gold; Description: 8-BIT SHIFT/LOAD (INPUT) REGISTER, 16-SOIC; Height: 1.58mm; Lead Free: Lead Free; Length: 9.9mm; Library Name: TexasInstruments.SchLib; Manufacturer Part Number: SN74HC165DR; Max Operating Temperature: 125°C; Min Operating Temperature: -40°C; Mount: Surface Mount; Number of Bits per Element: 8; Number of Elements: 1; Number of Output Lines: 1; Number of Pins: 16; Package Quantity: 2500; Packaging: Tape and Reel; Pricing 1: 1=0.41000, 10=0.32900, 25=0.30120, 100=0.22400, 250=0.20300, 500=0.16800, 1000=0.12600 (USD); Radiation Hardening: No; REACH SVHC: No SVHC; RoHS: Yes; Stock 1: 11076; Supplier 1: Digi-Key; Supplier 2: Mouser; Supplier 3: RSComponents; Supplier Part Number 1: 296-8250-1-ND; Supplier Part Number 2: 595-SN74HC165DR; Supplier Part Number 3: 526640; Weight: 0.004998oz; Width: 3.91mm
U3 = TLV61220: IC ADJ Dc-DC 0.22A SOT23; Manufacturer: Texas Instruments; Efficiency: 95%; Max Input Voltage: 5.5V; Max Output Current: 400mA; Min Input Voltage: 700mV; Min Output Voltage: 1.8V; Number of Outputs: 1; Output Configuration: Positive; Output Current: 200mA; Output Type: Adjustable; Quiescent Current: 5uA; Switching Frequency: 2MHz; Synchronous Rectifier: Yes; Topology: Boost; Case/Package: SOT-23-6; Category: Voltage Regulators - Switching; Component Kind: Standard; ComponentLink5Description: TI datasheet online; ComponentLink5URL: https://datasheet.ciiva.com/14273/tlv61220-14273197.pdf; Height: 1.3mm; Lead Free: Lead Free; Length: 3.05mm; Library Name: TexasInstruments.SchLib; Max Operating Temperature: 85°C; Max Output Voltage: 5.5V; Min Operating Temperature: -40°C; Mount: Surface Mount; Number of Pins: 6; Output Voltage: 5.5V; Package Quantity: 1; Packaging: Tape and Reel; Pricing 1: 1=0.99000, 10=0.88500, 25=0.84000, 100=0.64500, 250=0.57000, 500=0.54000, 1000=0.42000 (USD); Radiation Hardening: No; REACH SVHC: No SVHC; RoHS: Yes; Stock 1: 42159; Supplier 1: Digi-Key; Supplier 2: Farnell; Supplier 3: Mouser; Supplier Part Number 1: 296-30547-1-ND; Supplier Part Number 2: 3007284; Supplier Part Number 3: 595-TLV61220DBVR; Width: 1.75mm
U4 = TPS2553DBVR: POWER LOAD SWITCH, HIGH SIDE, SOT-23-6; Power Load Switch Type:High Side; Input Voltage:6.5V; Current Limit:1.7A; On State Resistance:0.085ohm; Distribution Switch Case Style:SOT-23; No. of Pins:6; Thermal Protection:Yes; On / Enable;RoHS Compliant: Yes; Manufacturer: Texas Instruments; Max Input Voltage: 6.5V; Max Power Dissipation: 625mW; Min Input Voltage: 2.5V; Number of Outputs: 1; On-State Resistance: 85mR; Output Current: 1.5A; Case/Package: SOT-23; Category: Hot Swap Controllers; Component Kind: Standard; ComponentLink1Description: TI datasheet online; ComponentLink1URL: https://datasheet.ciiva.com/15108/getdatasheetpartid-297517-15108509.pdf; Lead Free: Lead Free; Library Name: TexasInstruments.SchLib; Manufacturer Part Number: TPS2553DBVR; Max Operating Temperature: 85°C; Max Supply Voltage: 6.5V; Min Operating Temperature: -40°C; Min Supply Voltage: 2.5V; Number of Pins: 6; Package Quantity: 1; Packaging: Tape and Reel; Pricing 1: 1=1.20000, 10=1.07100, 25=1.01640, 100=0.78050, 250=0.68972, 500=0.65340, 1000=0.50820 (USD); Radiation Hardening: No; REACH SVHC: No SVHC; RoHS: Yes; Stock 1: 14713; Supplier 1: Digi-Key; Supplier 2: Farnell; Supplier 3: Mouser; Supplier Part Number 1: 296-32464-1-ND; Supplier Part Number 2: 3124082; Supplier Part Number 3: 595-TPS2553DBVR; Weight: 0.00127oz
U5 = NCP114ASN330T1G: ON SEMICONDUCTOR - NCP114ASN330T1G - LDO Voltage Regulator, Fixed, 1.7 V to 5.5 V in, 3.3 V/300 mA out, 150 mV drop, TSOP-5; Dropout Voltage: 225mV; Max Input Voltage: 5.5V; Number of Regulators: 1; Output Configuration: Positive; Power Supply Rejection Ratio (PSRR): 75dB; Quiescent Current: 95uA; Case/Package: SOT-23-5; Category: Voltage Regulators - Linear; Component Kind: Standard; ComponentLink3Description: On Semi datasheet online; ComponentLink3URL: http://www.onsemi.com/pub/Collateral/NCP114-D.PDF; Lead Free: Lead Free; Library Name: OnSemiconductor.SchLib; Manufacturer: ON Semiconductor; Manufacturer Part Number: NCP114ASN330T1G; Max Operating Temperature: 85°C; Min Operating Temperature: -40°C; Mount: Surface Mount; Output Type: Fixed; Packaging: Cut Tape; Pricing 1: 1=0.33000, 10=0.24900, 25=0.21760, 100=0.12440, 250=0.11664, 500=0.09330, 1000=0.07153 (USD); RoHS: No; Stock 1: 32529; Supplier 1: Digi-Key; Supplier 2: RSComponents; Supplier 3: Mouser; Supplier Part Number 1: NCP114ASN330T1GOSCT-ND; Supplier Part Number 2: 1263178; Supplier Part Number 3: 863-NCP114ASN330T1G; Checked: ?; Created: DG
